# Altium schematic sheet [22] - MPSoC DECOUPLING.SchDoc("[22] - MPSoC DECOUPLING")
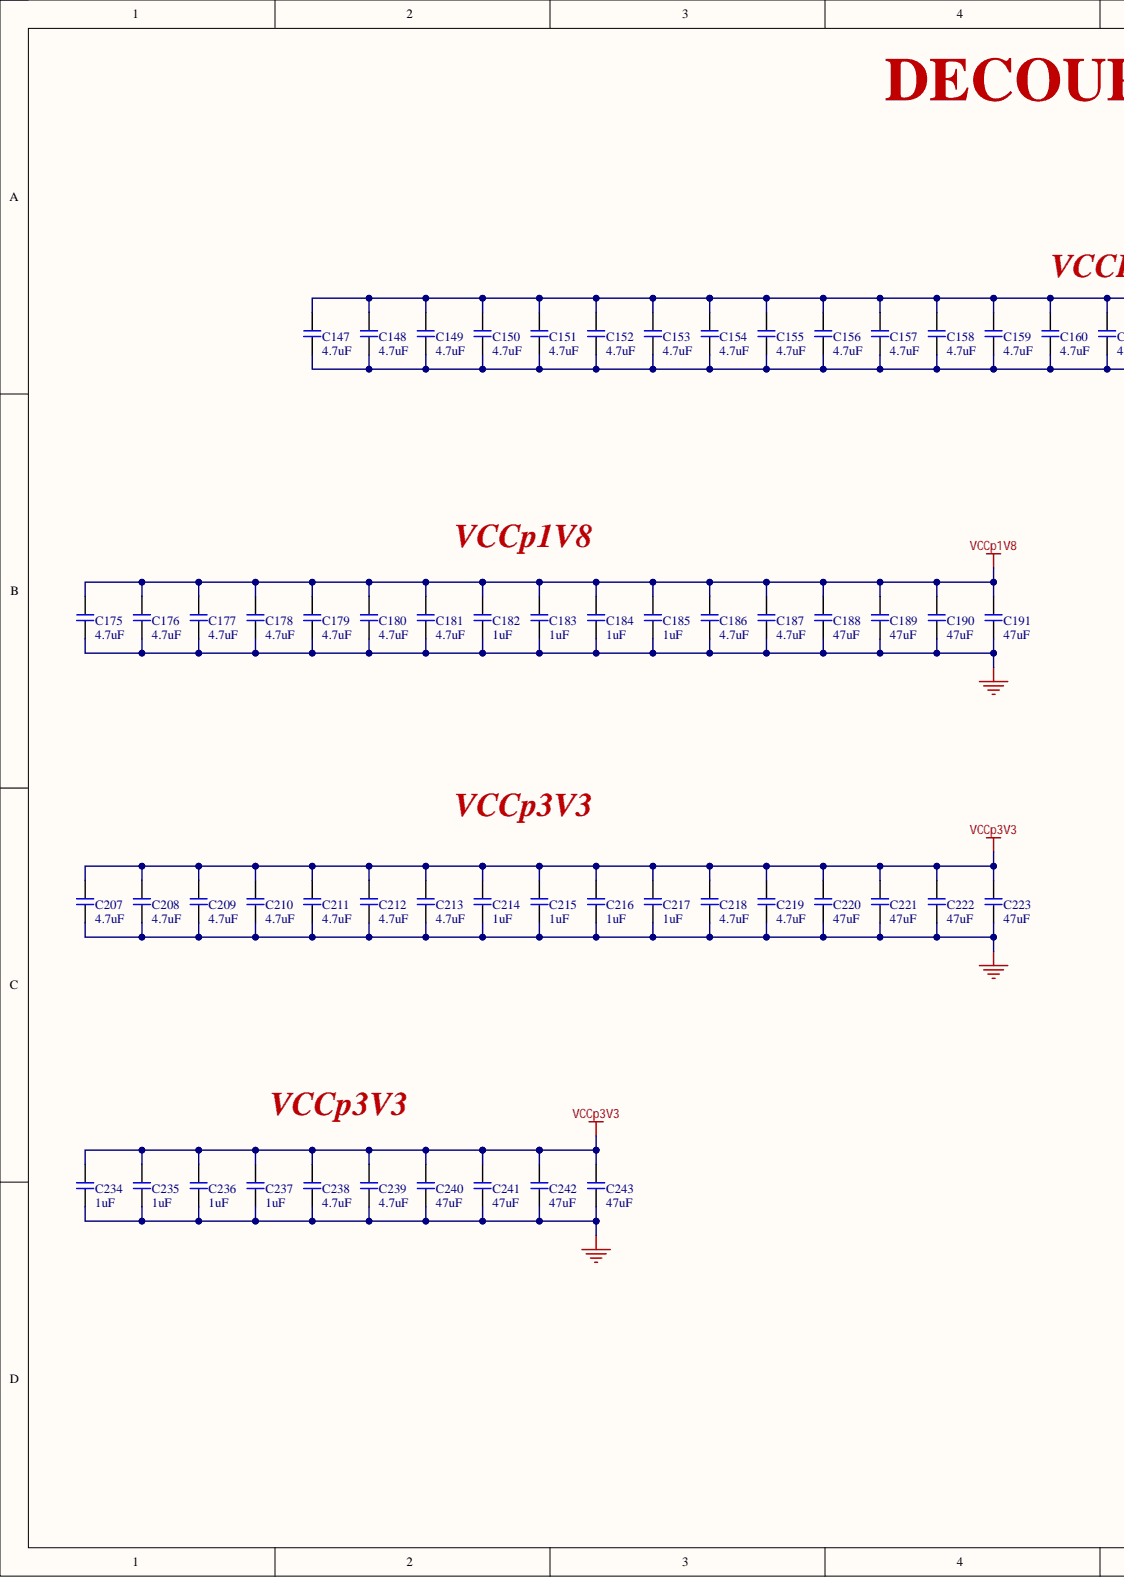
[diagram p0: [22] - MPSoC DECOUPLING.SchDoc, left]
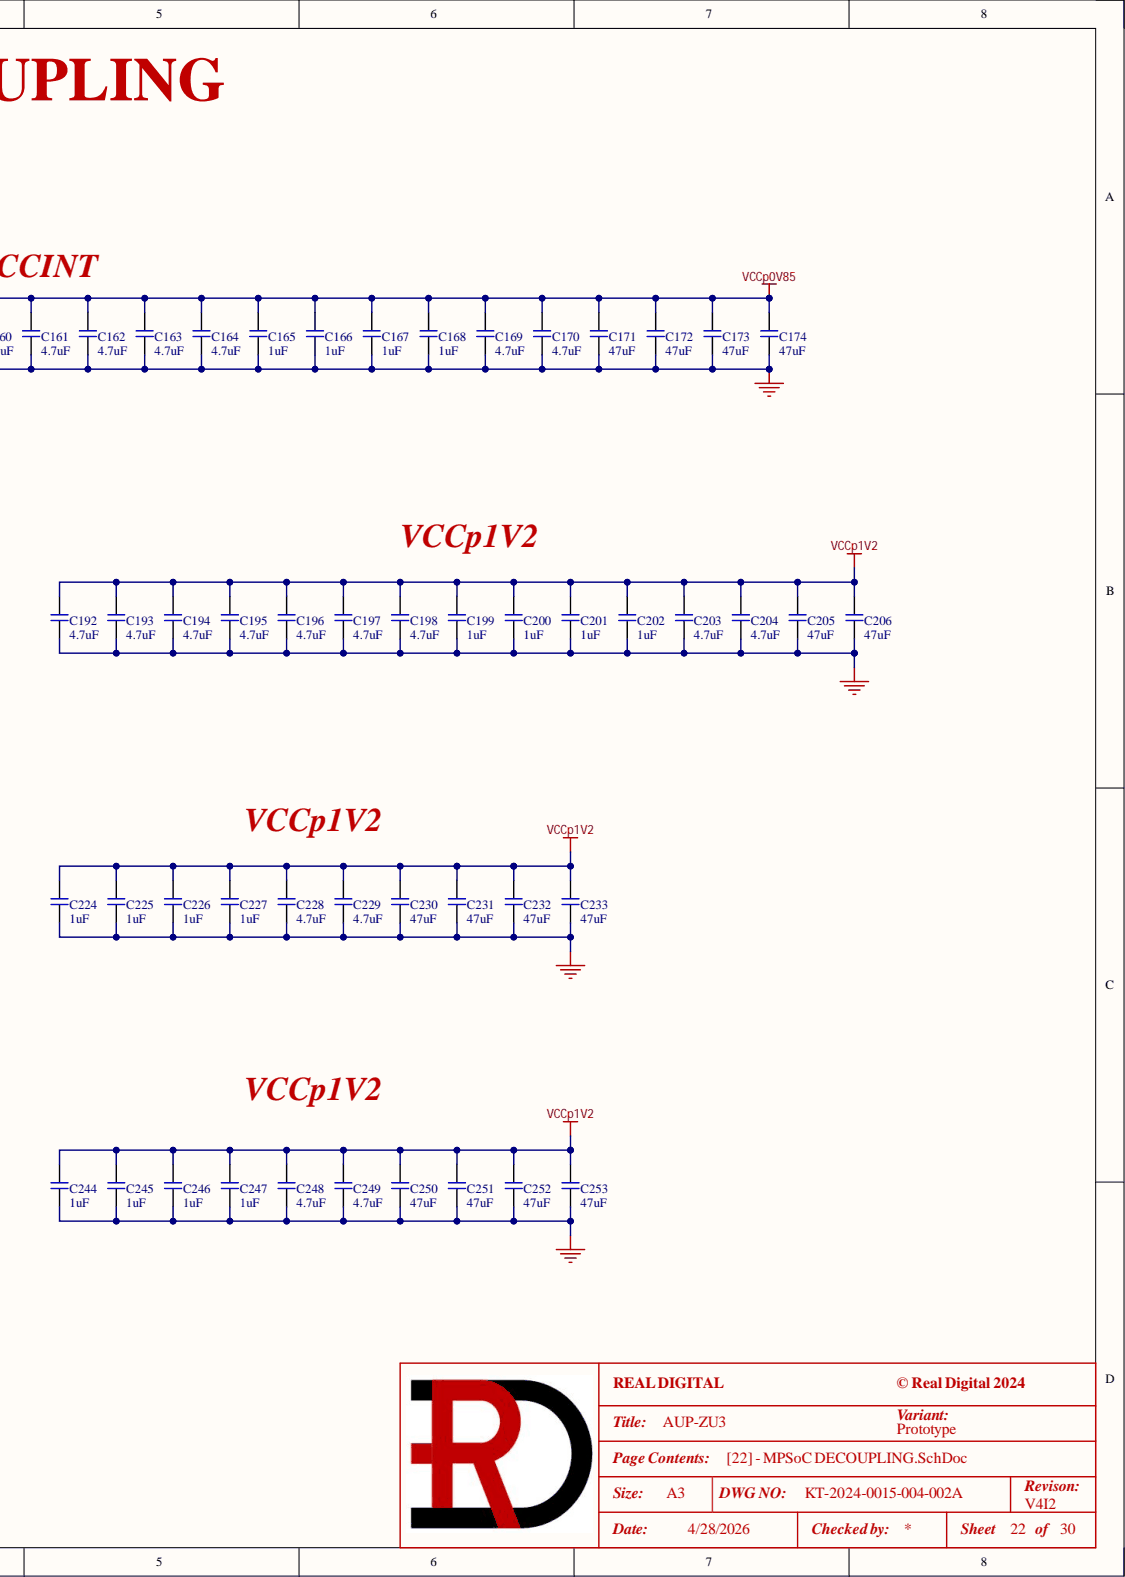
[diagram p1: [22] - MPSoC DECOUPLING.SchDoc, right]

## Parts
C147  4.7uF  [C0201]
C148  4.7uF  [C0201]
C149  4.7uF  [C0201]
C150  4.7uF  [C0201]
C151  4.7uF  [C0201]
C152  4.7uF  [C0201]
C153  4.7uF  [C0201]
C154  4.7uF  [C0201]
C155  4.7uF  [C0201]
C156  4.7uF  [C0201]
C157  4.7uF  [C0201]
C158  4.7uF  [C0201]
C159  4.7uF  [C0201]
C160  4.7uF  [C0201]
C161  4.7uF  [C0201]
C162  4.7uF  [C0201]
C163  4.7uF  [C0201]
C164  4.7uF  [C0201]
C165  1uF  [C0603]
C166  1uF  [C0603]
C167  1uF  [C0603]
C168  1uF  [C0603]
C169  4.7uF  [C0805]
C170  4.7uF  [C0805]
C171  47uF  [C1210]
C172  47uF  [C1210]
C173  47uF  [C1210]
C174  47uF  [C1210]
C175  4.7uF  [C0201]
C176  4.7uF  [C0201]
C177  4.7uF  [C0201]
C178  4.7uF  [C0201]
C179  4.7uF  [C0201]
C180  4.7uF  [C0201]
C181  4.7uF  [C0201]
C182  1uF  [C0603]
C183  1uF  [C0603]
C184  1uF  [C0603]
C185  1uF  [C0603]
C186  4.7uF  [C0805]
C187  4.7uF  [C0805]
C188  47uF  [C1210]
C189  47uF  [C1210]
C190  47uF  [C1210]
C191  47uF  [C1210]
C192  4.7uF  [C0201]
C193  4.7uF  [C0201]
C194  4.7uF  [C0201]
C195  4.7uF  [C0201]
C196  4.7uF  [C0201]
C197  4.7uF  [C0201]
C198  4.7uF  [C0201]
C199  1uF  [C0603]
C200  1uF  [C0603]
C201  1uF  [C0603]
C202  1uF  [C0603]
C203  4.7uF  [C0805]
C204  4.7uF  [C0805]
C205  47uF  [C1210]
C206  47uF  [C1210]
C207  4.7uF  [C0201]
C208  4.7uF  [C0201]
C209  4.7uF  [C0201]
C210  4.7uF  [C0201]
C211  4.7uF  [C0201]
C212  4.7uF  [C0201]
C213  4.7uF  [C0201]
C214  1uF  [C0603]
C215  1uF  [C0603]
C216  1uF  [C0603]
C217  1uF  [C0603]
C218  4.7uF  [C0805]
C219  4.7uF  [C0805]
C220  47uF  [C1210]
C221  47uF  [C1210]
C222  47uF  [C1210]
C223  47uF  [C1210]
C224  1uF  [C0603]
C225  1uF  [C0603]
C226  1uF  [C0603]
C227  1uF  [C0603]
C228  4.7uF  [C0805]
C229  4.7uF  [C0805]
C230  47uF  [C1210]
C231  47uF  [C1210]
C232  47uF  [C1210]
C233  47uF  [C1210]
C234  1uF  [C0603]
C235  1uF  [C0603]
C236  1uF  [C0603]
C237  1uF  [C0603]
C238  4.7uF  [C0805]
C239  4.7uF  [C0805]
C240  47uF  [C1210]
C241  47uF  [C1210]
C242  47uF  [C1210]
C243  47uF  [C1210]
C244  1uF  [C0603]
C245  1uF  [C0603]
C246  1uF  [C0603]
C247  1uF  [C0603]
C248  4.7uF  [C0805]
C249  4.7uF  [C0805]
C250  47uF  [C1210]
C251  47uF  [C1210]
C252  47uF  [C1210]
C253  47uF  [C1210]

## Nets
GND: C147.1 C148.1 C149.1 C150.1 C151.1 C152.1 C153.1 C154.1 C155.1 C156.1 C157.1 C158.1 C159.1 C160.1 C161.1 C162.1 C163.1 C164.1 C165.1 C166.1 C167.1 C168.1 C169.1 C170.1 C171.1 C172.1 C173.1 C174.1 C175.1 C176.1 C177.1 C178.1 C179.1 C180.1 C181.1 C182.1 C183.1 C184.1 C185.1 C186.1 C187.1 C188.1 C189.1 C190.1 C191.1 C192.1 C193.1 C194.1 C195.1 C196.1 C197.1 C198.1 C199.1 C200.1 C201.1 C202.1 C203.1 C204.1 C205.1 C206.1 C207.1 C208.1 C209.1 C210.1 C211.1 C212.1 C213.1 C214.1 C215.1 C216.1 C217.1 C218.1 C219.1 C220.1 C221.1 C222.1 C223.1 C224.1 C225.1 C226.1 C227.1 C228.1 C229.1 C230.1 C231.1 C232.1 C233.1 C234.1 C235.1 C236.1 C237.1 C238.1 C239.1 C240.1 C241.1 C242.1 C243.1 C244.1 C245.1 C246.1 C247.1 C248.1 C249.1 C250.1 C251.1 C252.1 C253.1
VCCp0V85: C147.2 C148.2 C149.2 C150.2 C151.2 C152.2 C153.2 C154.2 C155.2 C156.2 C157.2 C158.2 C159.2 C160.2 C161.2 C162.2 C163.2 C164.2 C165.2 C166.2 C167.2 C168.2 C169.2 C170.2 C171.2 C172.2 C173.2 C174.2
VCCp1V2: C192.2 C193.2 C194.2 C195.2 C196.2 C197.2 C198.2 C199.2 C200.2 C201.2 C202.2 C203.2 C204.2 C205.2 C206.2 C224.2 C225.2 C226.2 C227.2 C228.2 C229.2 C230.2 C231.2 C232.2 C233.2 C244.2 C245.2 C246.2 C247.2 C248.2 C249.2 C250.2 C251.2 C252.2 C253.2
VCCp1V8: C175.2 C176.2 C177.2 C178.2 C179.2 C180.2 C181.2 C182.2 C183.2 C184.2 C185.2 C186.2 C187.2 C188.2 C189.2 C190.2 C191.2
VCCp3V3: C207.2 C208.2 C209.2 C210.2 C211.2 C212.2 C213.2 C214.2 C215.2 C216.2 C217.2 C218.2 C219.2 C220.2 C221.2 C222.2 C223.2 C234.2 C235.2 C236.2 C237.2 C238.2 C239.2 C240.2 C241.2 C242.2 C243.2

## Library data of the parts
C147-C164 C175-C181 C192-C198 C207-C213 = GRM035R60J475ME15J: CAP CER 4.7uF 6.3V X5R 0201; Manufacturer 1: Murata Electronics; .Checked_PCB: RV; C P/N: RD-111-0106; LoadStatus: Load; Tolerance: 20%; Category: Capacitors; Component Kind: Standard; Library Name: KT-RealDigital.IntLib; Manufacturer 1 Part Number: GRM035R60J475ME15J; Temperature Coefficient: X5R; Voltage - Rated: 6.3V; .Checked_SCH: RV; .Creator: RV
C165-C168 C182-C185 C199-C202 C214-C217 C224-C227 C234-C237 C244-C247 = GCJ188R71E105KA01D: CAP CER 1uF 25V X7R 0603; Manufacturer 1: Murata Electronics; .Checked_PCB: AC; .Creator: RV; C P/N: 111-0602; LoadStatus: Load; Tolerance: 10%; Category: Capacitors; Component Kind: Standard; Library Name: KT-RealDigital.IntLib; Manufacturer 1 Part Number: GCJ188R71E105KA01D; Temperature Coefficient: X7R; Voltage - Rated: 25V; .Checked_SCH: AC
C169 C170 C186 C187 C203 C204 C218 C219 C228 C229 C238 C239 C248 C249 = GRM21BR71C475KE51L: CAP CER 4.7uF 16V X7R 0805; Manufacturer 1: Murata Electronics; .Checked_PCB: AC; .Creator: RV; C P/N: 111-0609; LoadStatus: Load; Tolerance: 10%; Category: Capacitors; Component Kind: Standard; Library Name: KT-RealDigital.IntLib; Manufacturer 1 Part Number: GRM21BR71C475KE51L; Temperature Coefficient: X7R; Voltage - Rated: 16V; .Checked_SCH: AC
C171-C174 C188-C191 C205 C206 C220-C223 C230-C233 C240-C243 C250-C253 = GRM32EC81C476KE15K: CAP CER 47uF 16V X6S 1210; Manufacturer 1: Murata Electronics; Manufacturer 2 Part Number: GRM32EC81C476KE15L; .Checked_PCB: AC; .Creator: RV; C P/N: RD-111-0007; LoadStatus: Load; Tolerance: 10%; Category: Capacitors; Component Kind: Standard; Library Name: KT-RealDigital.IntLib; Manufacturer 1 Part Number: GRM32EC81C476KE15K; Manufacturer 2: Murata Electronics; Temperature Coefficient: X6S; Voltage - Rated: 16V; .Checked_SCH: AC
